# Altium schematic sheet CAN.SchDoc
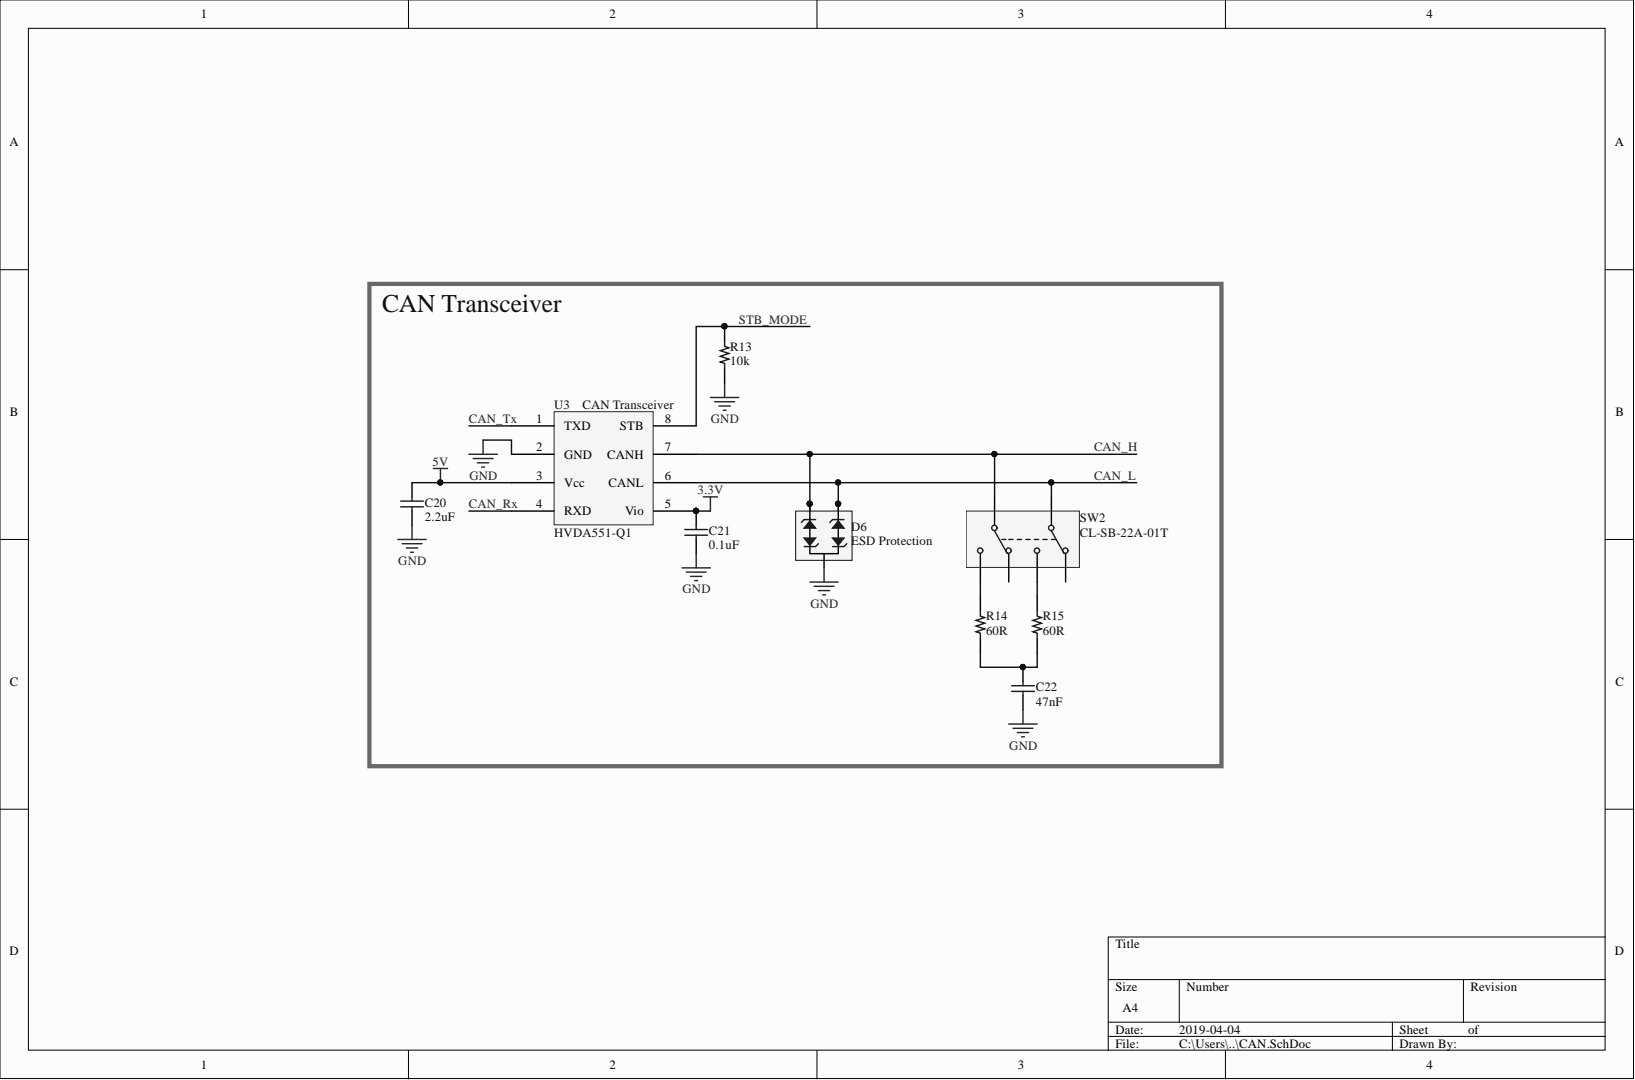
[diagram p0: CAN.SchDoc]

## Parts
C20  value 2.2uF  [C0603]  (hidden comment: CAP CER 0603 2.2uF 6.3V X7R 10%)
C21  value 0.1uF  [C0603]  (hidden comment: CAP CER 0603 0.1uF 50V C0G 10%)
C22  value 47nF  [C0603]  (hidden comment: CAP CER 0603 47nF 50V X7R 10%)
D6  ESD Protection  [SOT323]
R13  value 10k  [R0603]  (hidden comment: RES 0603 10k 1% 0.1W)
R14  value 60R  [R0603]  (hidden comment: RES 0603 60R 1% 0.25W)
R15  value 60R  [R0603]  (hidden comment: RES 0603 60R 1% 0.25W)
SW2  CL-SB-22A-01T  [CL-SB-22A-01T]
U3  CAN Transceiver  [SOIC-8]

## Nets
3.3V: C21.2 U3.5(Vio)
5V: C20.2 U3.3(Vcc)
GND: C20.1 C21.1 C22.2 D6.3 R13.1 U3.2(GND)
CAN_H: D6.2 SW2.5 U3.7(CANH)
CAN_L: D6.1 SW2.2 U3.6(CANL)
CAN_Rx: U3.4(RXD)
CAN_Tx: U3.1(TXD)
STB_MODE: R13.2 U3.8(STB)
NetC22_1: C22.1 R14.1 R15.1
NetR14_2: R14.2 SW2.6
NetR15_2: R15.2 SW2.3

Not connected: SW2 1 4

## Library data of the parts
C20 = CAP CER 0603 2.2uF 6.3V X7R 10%: 2.2uF ceramic capacitor; Manufacturer: TDK Corporation; Part_Number: CGA3E1X7R0J225K080AC; Component Kind: Standard; Library Name: Capacitors.SchLib; Price: 0.34; Supplier 1: Digi-Key; Supplier_Link: http://www.digikey.ca/product-detail/en/CGA3E1X7R0J225K080AC/445-6933-1-ND/2672951; Supplier Part Number 1: 445-6933-1-ND; Tolerance: 10%; Voltage rating: 6.3V
C21 = CAP CER 0603 0.1uF 50V C0G 10%: 0.1uF ceramic capacitor; Manufacturer: Murata Electronics North America; Part_Number: GCM188R71H104KA57D; Component Kind: Standard; Library Name: Capacitors.SchLib; Price: 0.45; Supplier 1: Digi-Key; Supplier_Link: https://www.digikey.ca/product-detail/en/murata-electronics-north-america/GCM188R71H104KA57D/490-4779-1-ND/1641698; Supplier Part Number 1: 490-4779-1-ND; Tolerance: 10%; Voltage rating: 50V
C22 = CAP CER 0603 47nF 50V X7R 10%: 47nF ceramic capacitor; Manufacturer: Murata Electronics North America; Part_Number: GCJ188R71H473KA12D; Component Kind: Standard; Library Name: Capacitors.SchLib; Price: 0.45; Supplier 1: Digi-Key; Supplier_Link: https://www.digikey.ca/product-detail/en/murata-electronics-north-america/GCJ188R71H473KA12D/490-5854-1-ND/2785840; Supplier Part Number 1: 490-5854-1-ND; Tolerance: 10%; Voltage rating: 50V
D6 = PESD1CAN-UX: CAN bus ESD protection diode; Manufacturer: NXP; Component Kind: Standard; Library Name: Diodes.SchLib; Part_Number: PESD1CAN-UX; Price: 0.51; Price_10: 0.417; Supplier 1: Digi-Key; Supplier_Link: http://www.digikey.ca/product-detail/en/nxp-semiconductors/PESD1CAN-UX/568-10731-1-ND/4386316; Supplier Part Number 1: 568-10731-2-ND
R13 = RES 0603 10k 1% 0.1W: 10 kOhms 1% 0.1W Resistor; Manufacturer: Panasonic Electronic Components; Part_Number: ERJ-3EKF1002V; Component Kind: Standard; Library Name: Resistors.SchLib; Power: 0.1W; Price_10: 0.095; Supplier 1: Digi-Key; Supplier_Link: https://www.digikey.ca/product-detail/en/panasonic-electronic-components/ERJ-3EKF1002V/P10.0KHCT-ND/198102; Supplier Part Number 1: P10.0KHCT-ND; Tolerance: 1%
R14 R15 = RES 0603 60R 1% 0.25W: 60 Ohms 0.25W Resistor; Manufacturer: Rohm Semiconductor; Part_Number: ESR03EZPJ620; Tolerance: 5%; Component Kind: Standard; Library Name: Resistors.SchLib; Power: 0.25W; Price: 0.15; Supplier 1: Digi-Key; Supplier_Link: http://www.digikey.ca/product-detail/en/ESR03EZPJ620/RHM62DCT-ND/1983794; Supplier Part Number 1: RHM62DCT-ND
SW2 = CL-SB-22A-01T: SWITCH SLIDE DPDT 200MA 12VDC; Manufacturer: Copal Electronics Inc.; Component Kind: Standard; Library Name: Other.SchLib; Part_Number: CL-SB-22A-01T; Price: 1.4; Price_10: 1.347; Supplier 1: Digi-Key; Supplier_Link: http://www.digikey.ca/product-detail/en/copal-electronics-inc/CL-SB-22A-01T/563-1338-1-ND/3507893; Supplier Part Number 1: 563-1338-1-ND
U3 = HVDA551-Q1: Automotive 5-V CAN Transceiver with I/O Level Adapting and Low-Power Mode Supply Optimization; Manufacturer: Texas Instrument; Component Kind: Standard; Library Name: IC.SchLib; Part_Number: HVDA551-Q1; Price: 2.450; Price_10: 2.201; Sample_Link: http://www.ti.com/product/HVDA551-Q1/samplebuy; Supplier 1: Digi-Key; Supplier_Link: http://www.digikey.ca/product-detail/en/HVDA551QDRQ1/296-40116-1-ND/5177993; Supplier Part Number 1: 296-40116-1-ND
